# Altium schematic sheet pw_contr_v1_s2.SchDoc(pw_contr_v1_s2)
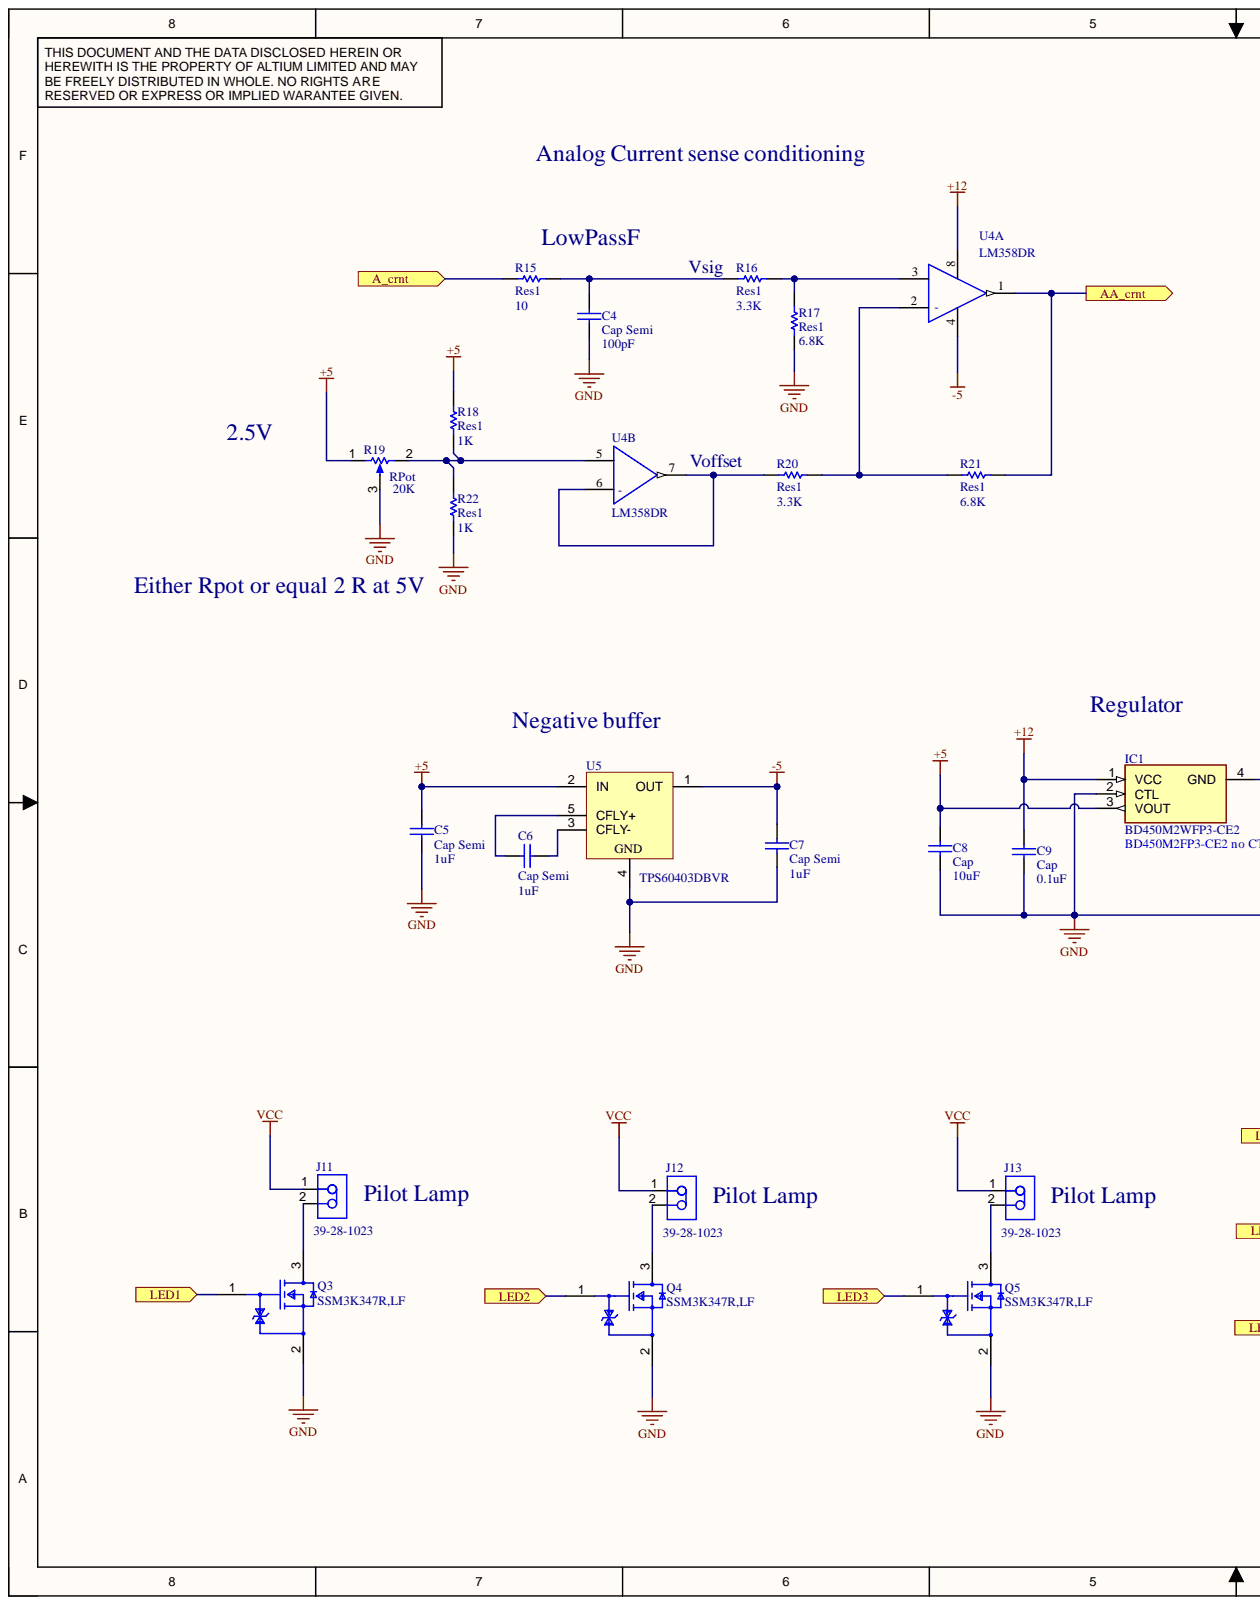
[diagram p0: pw_contr_v1_s2.SchDoc, left]
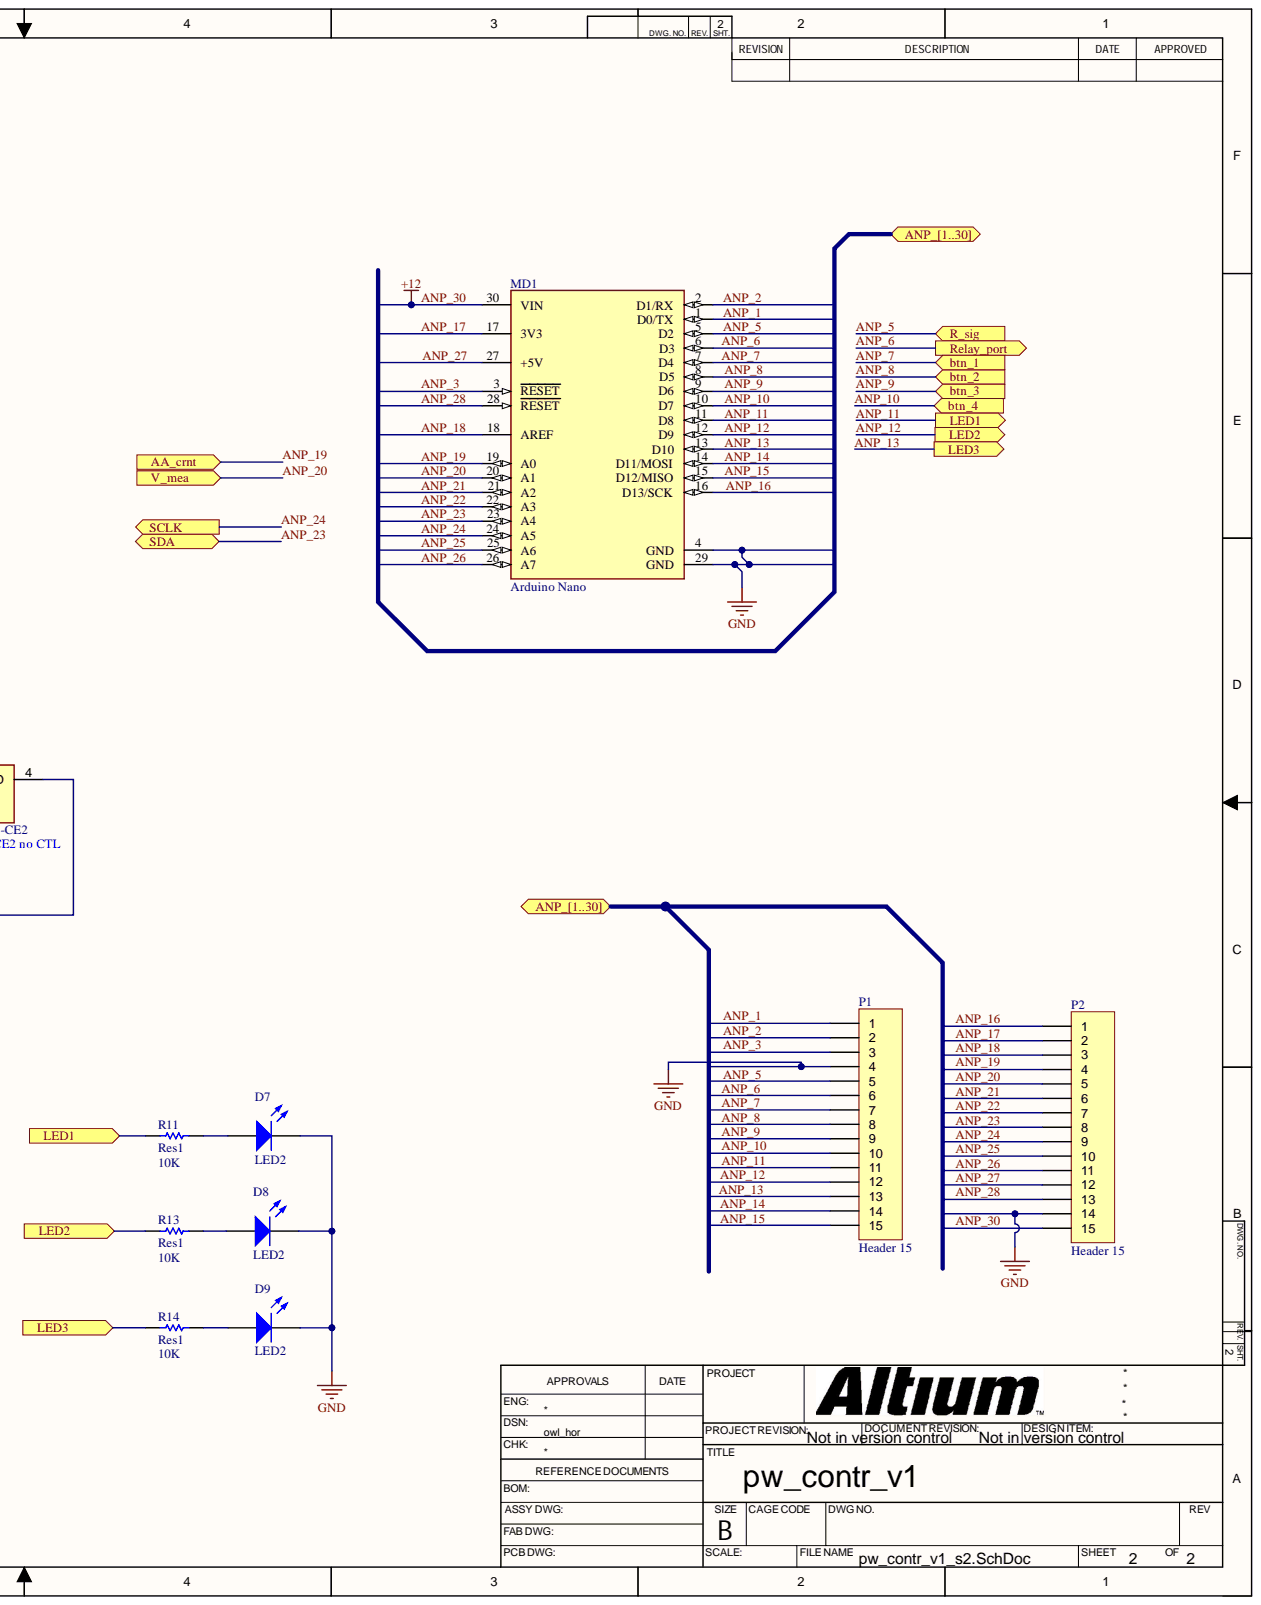
[diagram p1: pw_contr_v1_s2.SchDoc, right]

## Parts
C4  Cap Semi  value 100pF  [C1206]
C5  Cap Semi  value 1uF  [C1206]
C6  Cap Semi  value 1uF  [C1206]
C7  Cap Semi  value 1uF  [C1206]
C8  Cap  value 10uF  [RAD-0.3]
C9  Cap  value 0.1uF  [RAD-0.3]
D7  LED2  [3.2X1.6X1.1]
D8  LED2  [3.2X1.6X1.1]
D9  LED2  [3.2X1.6X1.1]
IC1  BD450M2WFP3-CE2  [SOT230P700X170-4N]
J11  39-28-1023  [MOLX-39-28-1023-2_V]
J12  39-28-1023  [MOLX-39-28-1023-2_V]
J13  39-28-1023  [MOLX-39-28-1023-2_V]
MD1  Arduino Nano  [Arduino_0_MFG]
P1  Header 15  [HDR1X15]
P2  Header 15  [HDR1X15]
Q3  SSM3K347R,LF  [FP-SOT-23F-MFG]
Q4  SSM3K347R,LF  [FP-SOT-23F-MFG]
Q5  SSM3K347R,LF  [FP-SOT-23F-MFG]
R11  Res1  value 10K  [AXIAL-0.3]
R13  Res1  value 10K  [AXIAL-0.3]
R14  Res1  value 10K  [AXIAL-0.3]
R15  Res1  value 10  [AXIAL-0.3]
R16  Res1  value 3.3K  [AXIAL-0.3]
R17  Res1  value 6.8K  [AXIAL-0.3]
R18  Res1  value 1K  [AXIAL-0.3]
R19  RPot  value 20K  [VR5]
R20  Res1  value 3.3K  [AXIAL-0.3]
R21  Res1  value 6.8K  [AXIAL-0.3]
R22  Res1  value 1K  [AXIAL-0.3]
U4  LM358DR  [FP-D0008A-MFG]  (parts A,B)
U5  TPS60403DBVR  [DBV0005A_N]

## Ports
A_crnt, AA_crnt, ANP_1, ANP_2, ANP_3, ANP_4, ANP_5, ANP_6, ANP_7, ANP_8, ANP_9, ANP_10, ANP_11, ANP_12, ANP_13, ANP_14, ANP_15, ANP_16, ANP_17, ANP_18, ANP_19, ANP_20, ANP_21, ANP_22, ANP_23, ANP_24, ANP_25, ANP_26, ANP_27, ANP_28, ANP_29, ANP_30, ANP_[1..30], btn_1, btn_2, btn_3, btn_4, LED1, LED2, LED3, R_sig, Relay_port, SCLK, SDA, V_mea

## Nets
+5: C5.2 C8.2 IC1.3(VOUT) R18.2 R19.1 U5.2(IN)
-5: C7.2 U4.4 U5.1(OUT)
GND: C4.2 C5.1 C7.1 C8.1 C9.1 D7.2 D8.2 D9.2 IC1.2(CTL) IC1.4(GND) MD1.4(GND) MD1.29(GND) P1.4 P2.14 Q3.2 Q4.2 Q5.2 R17.1 R19.3 R22.1 U5.4
VCC: J11.1 J12.1 J13.1
A_crnt: R15.1 ; port A_crnt
ANP_1: MD1.1(D0/TX) P1.1 ; port ANP_[1..30]  [also labelled ]
ANP_2: MD1.2(D1/RX) P1.2 ; port ANP_[1..30]  [also labelled ]
ANP_3: MD1.3(RESET) P1.3 ; port ANP_[1..30]  [also labelled ]
ANP_5: MD1.5(D2) P1.5 ; port ANP_[1..30], port R_sig  [also labelled ]
ANP_6: MD1.6(D3) P1.6 ; port ANP_[1..30], port Relay_port  [also labelled ]
ANP_7: MD1.7(D4) P1.7 ; port ANP_[1..30], port btn_1  [also labelled ]
ANP_8: MD1.8(D5) P1.8 ; port ANP_[1..30], port btn_2  [also labelled ]
ANP_9: MD1.9(D6) P1.9 ; port ANP_[1..30], port btn_3  [also labelled ]
ANP_10: MD1.10(D7) P1.10 ; port ANP_[1..30], port btn_4  [also labelled ]
ANP_11: MD1.11(D8) P1.11 Q3.1 R11.2 ; port ANP_[1..30], port LED1  [also labelled ]
ANP_12: MD1.12(D9) P1.12 Q4.1 R13.2 ; port ANP_[1..30], port LED2  [also labelled ]
ANP_13: MD1.13(D10) P1.13 Q5.1 R14.2 ; port ANP_[1..30], port LED3  [also labelled ]
ANP_14: MD1.14(D11/MOSI) P1.14 ; port ANP_[1..30]  [also labelled ]
ANP_15: MD1.15(D12/MISO) P1.15 ; port ANP_[1..30]  [also labelled ]
ANP_16: MD1.16(D13/SCK) P2.1 ; port ANP_[1..30]  [also labelled ]
ANP_17: MD1.17(3V3) P2.2 ; port ANP_[1..30]  [also labelled ]
ANP_18: MD1.18(AREF) P2.3 ; port ANP_[1..30]  [also labelled ]
ANP_19: MD1.19(A0) P2.4 R21.2 U4.1 ; port AA_crnt, port ANP_[1..30]  [also labelled ]
ANP_20: MD1.20(A1) P2.5 ; port ANP_[1..30], port V_mea  [also labelled ]
ANP_21: MD1.21(A2) P2.6 ; port ANP_[1..30]  [also labelled ]
ANP_22: MD1.22(A3) P2.7 ; port ANP_[1..30]  [also labelled ]
ANP_23: MD1.23(A4) P2.8 ; port ANP_[1..30], port SDA  [also labelled ]
ANP_24: MD1.24(A5) P2.9 ; port ANP_[1..30], port SCLK  [also labelled ]
ANP_25: MD1.25(A6) P2.10 ; port ANP_[1..30]  [also labelled ]
ANP_26: MD1.26(A7) P2.11 ; port ANP_[1..30]  [also labelled ]
ANP_27: MD1.27(+5V) P2.12 ; port ANP_[1..30]  [also labelled ]
ANP_28: MD1.28(RESET) P2.13 ; port ANP_[1..30]  [also labelled ]
ANP_30: C9.2 IC1.1(VCC) MD1.30(VIN) P2.15 U4.8 ; port ANP_[1..30]  [also labelled ]
NetC4_1: C4.1 R15.2 R16.1
NetC6_1: C6.1 U5.3(CFLY-)
NetC6_2: C6.2 U5.5(CFLY+)
NetD7_1: D7.1 R11.1
NetD8_1: D8.1 R13.1
NetD9_1: D9.1 R14.1
NetJ11_2: J11.2 Q3.3
NetJ12_2: J12.2 Q4.3
NetJ13_2: J13.2 Q5.3
NetR16_2: R16.2 R17.2 U4.3
NetR18_1: R18.1 R19.2 R22.2 U4.5
NetR20_1: R20.1 U4.6(-) U4.7
NetR20_2: R20.2 R21.1 U4.2(-)
N00001_4: ; port ANP_[1..30]
N00001_29: ; port ANP_[1..30]

## Library data of the parts
C4-C7 = Cap Semi: Capacitor (Semiconductor SIM Model); Component Kind: Standard; LatestRevisionDate: 29-May-2009; LatestRevisionNote: IPC-7351 Footprint Added.; Library Name: Miscellaneous Devices.IntLib; PackageDescription: Chip Capacitor; PackageReference: C1206; Published: 8-Jun-2000; Signal Integrity: Cap Semi; Simulation: CAP; Publisher: Altium Limited
C8 C9 = Cap: Capacitor; Component Kind: Standard; LatestRevisionDate: 17-Jul-2002; LatestRevisionNote: Re-released for DXP Platform.; Library Name: Miscellaneous Devices.IntLib; PackageDescription: Radial Cap, Thru-Hole; 2 Leads; 0.3 in Pin Spacing; PackageReference: RAD-0.3; Published: 8-Jun-2000; Signal Integrity: Cap; Simulation: CAP; Publisher: Altium Limited
D7-D9 = LED2: Typical RED, GREEN, YELLOW, AMBER GaAs LED; Component Kind: Standard; LatestRevisionDate: 17-Jul-2002; LatestRevisionNote: Re-released for DXP Platform.; Library Name: Miscellaneous Devices.IntLib; PackageDescription: SM LED; 2 Flat Leads; PackageReference: 3.2X1.6X1.1; PackageVersion: Feb-2002; Published: 8-Jun-2000; Simulation: LED2; Publisher: Altium Limited
IC1 = BD450M2WFP3-CE2: Integrated Circuit; Manufacturer_Name: ROHM Semiconductor; Component Kind: Standard; Datasheet Link: https://componentsearchengine.com/Datasheets/1/BD450M2WFP3-CE2.pdf; Height: 1.7mm; Manufacturer_Part_Number: BD450M2WFP3-CE2; Mouser Part Number: 755-BD450M2WFP3-CE2; Mouser Price/Stock: https://www.mouser.co.uk/ProductDetail/ROHM-Semiconductor/BD450M2WFP3-CE2?qs=pXoXL5qUNJ0Rp1aMnIGBZQ%3D%3D
J11-J13 = CMP-2000-05244-1: Female Header, Pitch 4.2 mm, 1 x 2 Position, Height 12.8 mm, Tail Length 3.5 mm, -40 to 105 degC, RoHS; Manufacturer: Molex; Connector Body Material: –; Connector Mounting: PCB; Connector Type: RectangularPower; Contact Termination: Solder; Current Rating: 13A; Mounting Orientation: Straight; No. of Contacts: 4; No. of Poles: 2; No. of Rows: 1; Pitch Spacing: 4.2mm; Voltage Rating V AC: 600V; Working Voltage: 250V; Colour: Clear; Component Kind: Standard; Connector Insert Type: Header; Connector Mounting Orientation: PCB; Contact Gender: –; Contact Material: Brass; Contact Plating: Tin; Datasheet URL: https://datasheet.ciiva.com/11746/0900766b80460d9e-11746456.pdf; Datasheet Version: Rev. F, 05/2004; Flammability Rating: UL94V-2; Gender: Header; Lead Spacing: 4.2mm; Library Name: Altium Content Vault; Manufacturer URL: http://www.molex.com/; Mounting Type: PCBoard; MSL: –; No. of Ways: 2; Series: Mini-FitJr; SVHC: –; Termination Method: Solder
MD1 = Arduino Nano_eec: Sch style pinout with CAD; Component Kind: Standard; Library Name: Schlib1.SchLib
P1 P2 = Header 15: Header, 15-Pin; Component Kind: Standard; LatestRevisionDate: 17-Jul-2002; LatestRevisionNote: Re-released for DXP Platform.; Library Name: Miscellaneous Connectors.IntLib; Signal Integrity: Connector; Publisher: Altium Limited
Q3-Q5 = CMP-35916-000012-1: MOSFET N-CHANNEL 20V 4A SOT23F; Component Kind: Standard; Library Name: Altium Content Vault
R11 R13-R18 R20-R22 = Res1: Resistor; Component Kind: Standard; LatestRevisionDate: 17-Jul-2002; LatestRevisionNote: Re-released for DXP Platform.; Library Name: Miscellaneous Devices.IntLib; PackageDescription: Axial Device, Thru-Hole; 2 Leads; 0.3 in Pin Spacing; PackageReference: AXIAL-0.3; Published: 8-Jun-2000; Signal Integrity: Res; Simulation: RESISTOR; Publisher: Altium Limited
R19 = RPot: Potentiometer; Set Position: 0.5; Sim Note: Enter the Resistance value and the set point into parameter fields.; Component Kind: Standard; LatestRevisionDate: 17-Jul-2002; LatestRevisionNote: Re-released for DXP Platform.; Library Name: Miscellaneous Devices.IntLib; PackageDescription: Variable Resistor, Thru-Hole; 3 Leads; PackageReference: VR5; Published: 8-Jun-2000; Simulation: POT; Publisher: Altium Limited
U4 = CMP-1685-00009-2: IC OPAMP GP 2 CIRCUIT 8SOIC; Bandwidth: 700kHz; Common Mode Rejection Ratio: 65dB; Dual Supply Voltage: 9V; Input Bias Current: 250nA; Input Offset Voltage (Vos): 7mV; Max Dual Supply Voltage: 16V; Max Supply Voltage: 32V; Min Dual Supply Voltage: 1.5V; Min Supply Voltage: 3V; Nominal Supply Current: 1.2mA; Number of Amplifiers: 2; Operating Supply Current: 1mA; Output Current: 30mA; Slew Rate: 0.3V/µs; Voltage Gain: 100dB; Case/Package: SOIC; Component Kind: Standard; Contact Plating: Gold; Gain Bandwidth Product: 700kHz; Height: 1.58mm; Lead Free: Lead Free; Length: 4.9mm; Library Name: Altium Content Vault; Max Operating Temperature: 70°C; Min Operating Temperature: 0°C; Mount: Surface Mount; Number of Channels: 2; Number of Circuits: 2; Number of Elements: 2; Number of Pins: 8; Operating Supply Voltage: 16V; Output Current per Channel: 30mA; Package Quantity: 2500; Packaging: Tape and Reel; Power Supply Rejection Ratio (PSRR): 65dB; Radiation Hardening: No; REACH SVHC: No SVHC; Unity Gain Bandwidth Product: 700kHz; Width: 3.91mm
U5 = CMP-0391-00006-2: -1.8 to -5.25 V, Inverting Charge Pump Regulator, 60 mA, 1.8 to 5.25 V Input with 2-Cell Alkaline / Nixx Input, -40 to 85 degC, 5-pin SOT23 (DBV5), Green (RoHS & no Sb/Br); Manufacturer: Texas Instruments; 2-Cell Alkaline/Nixx Input: TRUE; Efficiency(Typ)(%): 99; Iout(Max)(A): 0.06; Iq(Typ)(mA): 0.425; Switching Frequency(Max)(kHz): 300; Topology: Inverting Buck/Boost; Vin(Max)(V): 5.25; Vin(Min)(V): 1.8; Code_JEDEC: MO-178; Component Kind: Standard; ComponentLink1Description: Manufacturer URL; ComponentLink1URL: http://www.ti.com/; ComponentLink2Description: Datasheet; ComponentLink2URL: http://www.ti.com/general/docs/lit/getliterature.tsp?genericPartNumber=TPS60403&fileType=pdf; ComponentLink3Description: Package Specification; ComponentLink3URL: http://www.ti.com/litv/pdf/mpds018i; DatasheetVersion: SLVS324A; Eco-Plan: Green (RoHS & no Sb/Br); Library Name: Altium Content Vault; Mounting Technology: Surface Mount; PackageDescription: 5-Pin Plastic Small Outline, Body 1.60 Ãƒâ€” 2.90 mm, Pitch 0.95 mm; PackageReference: DBV0005A; PackageVersion: 4073253-4/K; PartNumber: TPS60403DBVR; Vout(Max)(V): -5.25; Vout(Min)(V): -1.8
